# Altium schematic sheet 01_Power.SchDoc
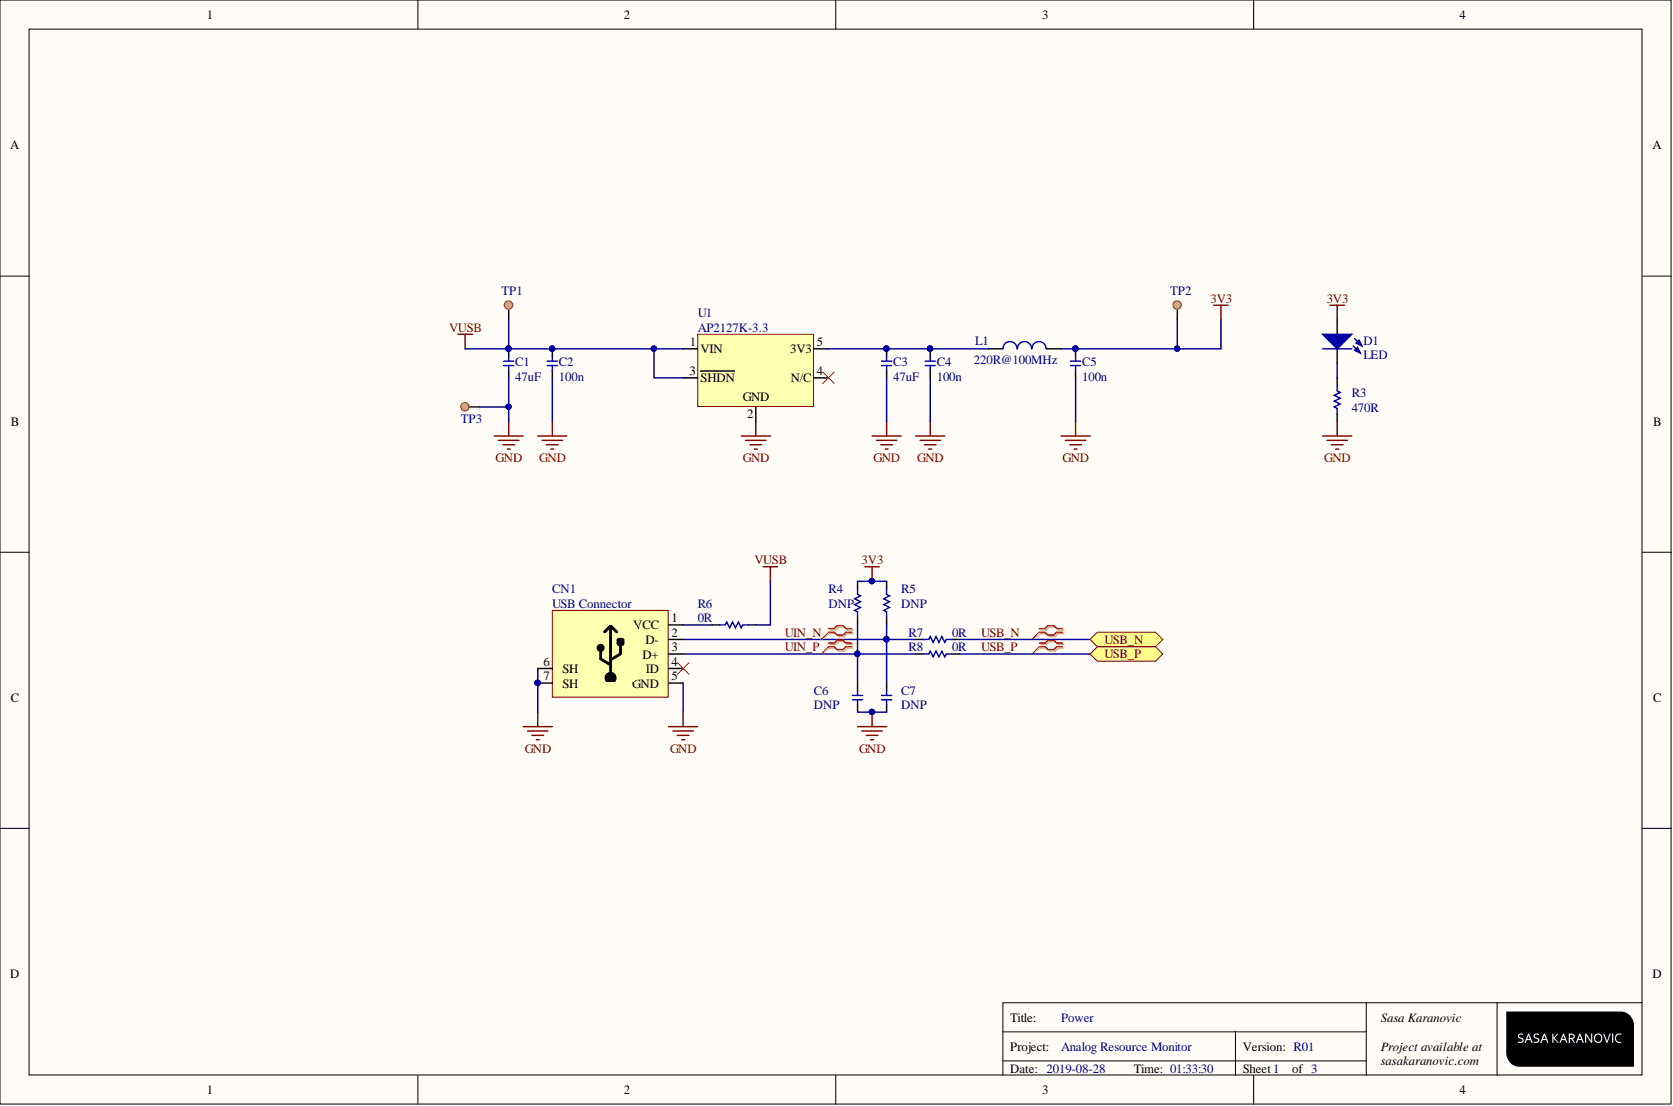
[diagram p0: 01_Power.SchDoc]

## Parts
C1  value 47uF  [0805]  (hidden comment: Cap)
C2  value 100n  [0603 - CAP]  (hidden comment: Cap)
C3  value 47uF  [0805]  (hidden comment: Cap)
C4  value 100n  [0603 - CAP]  (hidden comment: Cap)
C5  value 100n  [0603 - CAP]  (hidden comment: Cap)
C6  value DNP  [0603 - CAP]  (hidden comment: Cap)  (no BOM)
C7  value DNP  [0603 - CAP]  (hidden comment: Cap)  (no BOM)
CN1  USB Connector  [Amphenol FCI 10118192-0001LF]
D1  LED  [0603 Diode]
L1  value 220R@100MHz  [0603]  (hidden comment: Inductor)
R3  value 470R  [0603]  (hidden comment: Res)
R4  value DNP  [0603]  (hidden comment: Res)
R5  value DNP  [0603]  (hidden comment: Res)  (no BOM)
R6  value 0R  [0603]  (hidden comment: Res)
R7  value 0R  [0603]  (hidden comment: Res)
R8  value 0R  [0603]  (hidden comment: Res)
TP1  Test Point 0.6mm  [TP_SMD_1mm]  (value not drawn)  (no BOM)
TP2  Test Point 0.6mm  [TP_SMD_1mm]  (value not drawn)  (no BOM)
TP3  Test Point 0.6mm  [TP_SMD_1mm]  (value not drawn)  (no BOM)
U1  AP2127K-3.3  [SOT-23-5]

## Ports
USB_N, USB_P

## Nets
3V3: C5.2 D1.A L1.2 R4.1 R5.1 TP2.1
GND: C1.1 C2.1 C3.1 C4.1 C5.1 C6.1 C7.1 CN1.5(GND) CN1.6(SH) CN1.7(SH) R3.2 TP3.1 U1.2
VUSB: C1.2 C2.2 R6.1 TP1.1 U1.1(VIN) U1.3(SHDN)
UIN_N: C7.2 CN1.2(D-) R5.2 R7.2
UIN_P: C6.2 CN1.3(D+) R4.2 R8.2
USB_N: R7.1 ; port USB_N
USB_P: R8.1 ; port USB_P
NetC3_2: C3.2 C4.2 L1.1 U1.5(3V3)
NetCN1_1: CN1.1(VCC) R6.2
NetD1_K: D1.K R3.1

Not connected: CN1 4(ID); U1 4(N/C)

## Library data of the parts
C1 C3 = Cap: CAP TANT POLY47UF 20% 16V 1210; Manufacturer: AVX Corporation; Manufacturer Part Number: TCNT476M016R0200A; Component Kind: Standard; Distributor: DigiKey; Distributor Part Number: 478-9595-1-ND; Signal Integrity: Cap; Simulation: CAP; Library Name: Moji Schematici.SchLib
C2 C4-C7 = Cap: Capacitor; Manufacturer: AVX Corporation; Manufacturer Part Number: 06035C104KAT2A; Distributor: DigiKey; Distributor Part Number: 478-5052-1-ND; Signal Integrity: Cap; Simulation: CAP; Library Name: Moji Schematici.SchLib
  C2 C4 C5: Component Kind: Standard
CN1 = Amphenol FCI 10118192-0001LF: CONN USB MICRO B RECPT SMT R/A; Component Kind: Standard; Distributor: DigiKey; Distributor Part Number: 609-4613-1-ND; Manufacturer: Amphenol FCI; Manufacturer Part Number: 10118192-0001LF; Library Name: Moji Schematici.SchLib
D1 = LED: Typical RED GaAs LED; Component Kind: Standard; Distributor: DigiKey; Library Name: Moji Schematici.SchLib
L1 = Inductor: FERRITE BEAD 220 OHM 0603 1LN; Manufacturer: Murata Electronics North America; Manufacturer Part Number: BLM18KG221SN1D; Component Kind: Standard; Distributor: DigiKey; Distributor Part Number: 490-5255-1-ND; Signal Integrity: Inductor; Simulation: INDUCTOR; Library Name: Moji Schematici.SchLib
R3 = Res: Resistor; Manufacturer: Yageo; Manufacturer Part Number: RC0603FR-07470RL; Component Kind: Standard; Distributor: DigiKey; Distributor Part Number: 311-470HRCT-ND; Library Name: Moji Schematici.SchLib
R4-R8 = Res: Resistor; Manufacturer: Yageo; Manufacturer Part Number: RC0603JR-070RL; Distributor: DigiKey; Distributor Part Number: 311-0.0GRCT-ND; Library Name: Moji Schematici.SchLib
  R4 R6-R8: Component Kind: Standard
TP1-TP3 = TP: Library Name: Moji Schematici.SchLib
U1 = AP2127K-3.3TRG1: IC REG LINEAR 3.3V 300MA SOT23-5; Manufacturer: Diodes Incorporated; Component Kind: Standard; Distributor: DigiKey; Distributor Part Number: AP2127K-3.3TRG1DICT-ND; Manufacturer Part Number: AP2127K-3.3TRG1; Library Name: Moji Schematici.SchLib
